# Altium schematic sheet 8_JTAG1_FLASH1_PMOD.SchDoc(8_JTAG1_FLASH1_PMOD)
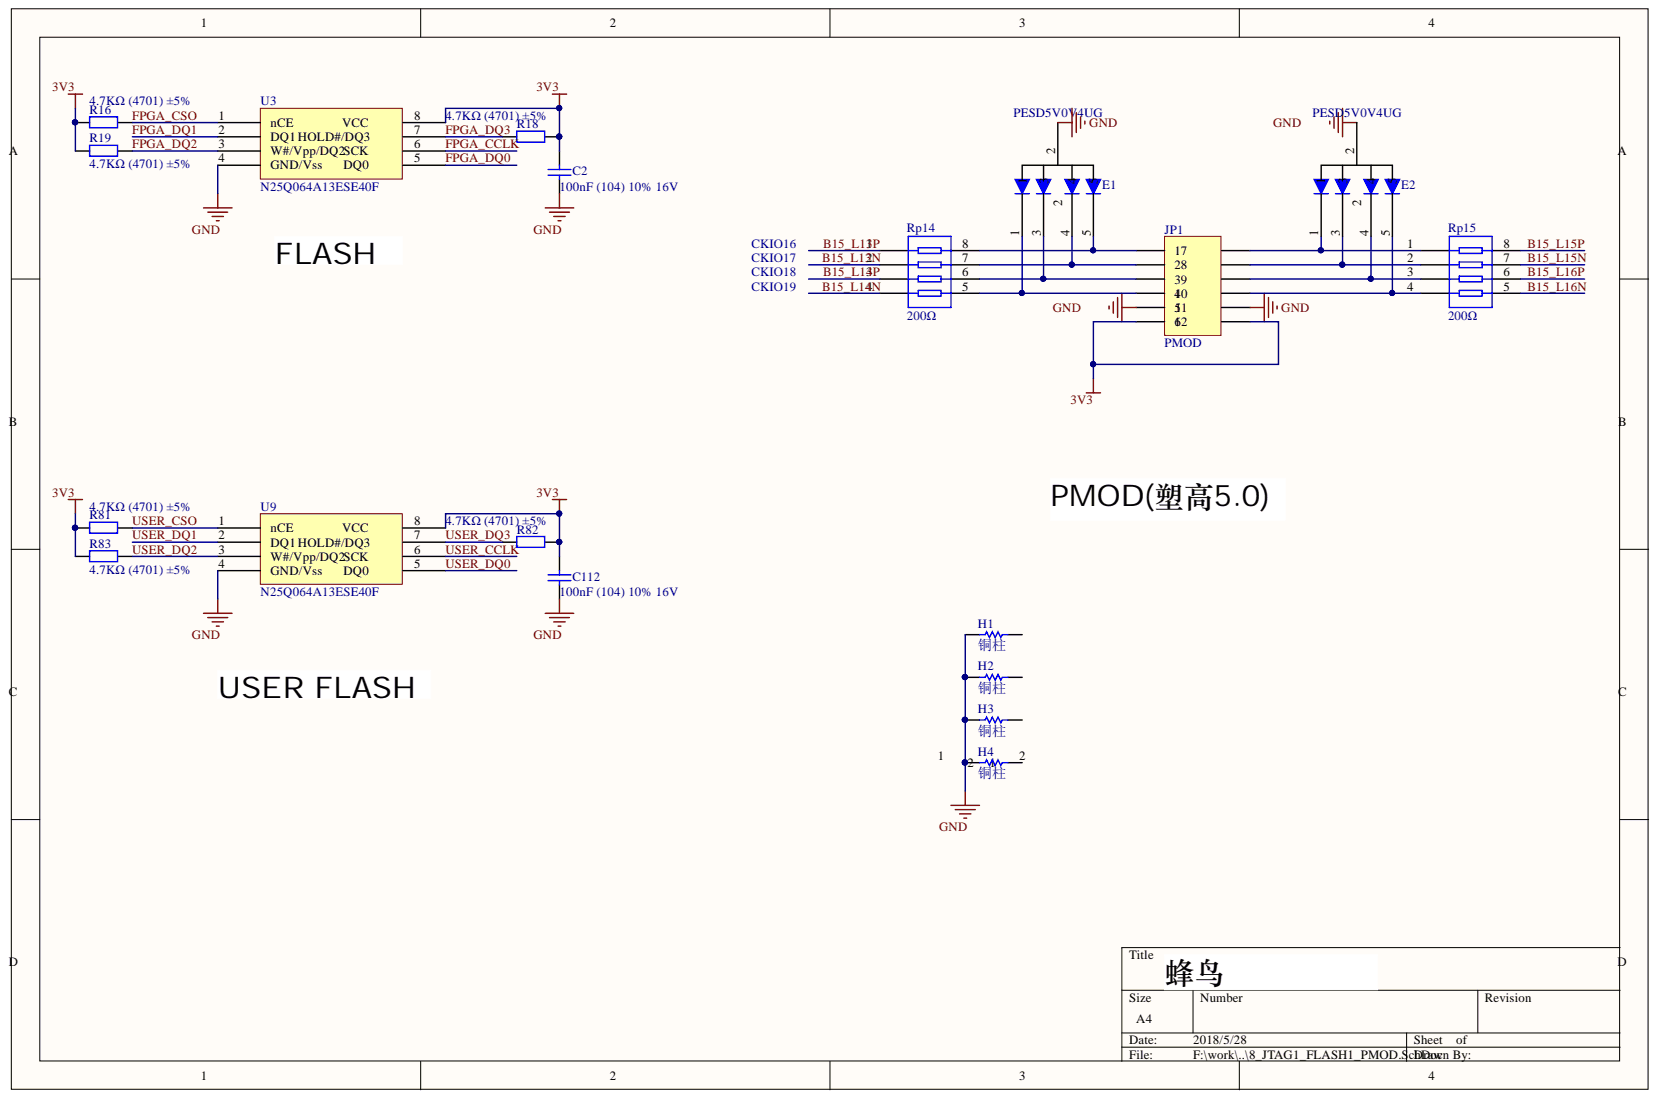
[diagram p0: 8_JTAG1_FLASH1_PMOD.SchDoc]

## Parts
C2  100nF (104) 10% 16V  [0402_C]
C112  100nF (104) 10% 16V  [0402_C]
E1  PESD5V0V4UG  [SOT353]
E2  PESD5V0V4UG  [SOT353]
H1  铜柱  [FPAD_1]
H2  铜柱  [FPAD_1]
H3  铜柱  [FPAD_1]
H4  铜柱  [FPAD_1]
JP1  PMOD  [PMOD]
R16  4.7KΩ (4701) ±5%  [0402_R]
R18  4.7KΩ (4701) ±5%  [0402_R]
R19  4.7KΩ (4701) ±5%  [0402_R]
R81  4.7KΩ (4701) ±5%  [0402_R]
R82  4.7KΩ (4701) ±5%  [0402_R]
R83  4.7KΩ (4701) ±5%  [0402_R]
Rp14  200Ω  [0402_Rx4]
Rp15  200Ω  [0402_Rx4]
U3  N25Q064A13ESE40F  [SO8W_208mil body]
U9  N25Q064A13ESE40F  [SO8W_208mil body]

## Nets
3V3: C2.2 C112.2 JP1.6 JP1.12 R16.1 R18.2 R19.1 R81.1 R82.2 R83.1 U3.8 U9.8
GND: C2.1 C112.1 E1.2 E2.2 H1.1 H2.1 H3.1 H4.1 JP1.5 JP1.11 U3.4(GND/Vss) U9.4(GND/Vss)
B15_L13N: Rp14.2
B15_L13P: Rp14.1
B15_L14N: Rp14.4
B15_L14P: Rp14.3
B15_L15N: Rp15.7
B15_L15P: Rp15.8
B15_L16N: Rp15.5
B15_L16P: Rp15.6
FPGA_CCLK: U3.6
FPGA_CSO: R16.2 U3.1(nCE)
FPGA_DQ0: U3.5
FPGA_DQ1: U3.2
FPGA_DQ2: R19.2 U3.3(W#/Vpp/DQ2)
FPGA_DQ3: R18.1 U3.7
USER_CCLK: U9.6
USER_CSO: R81.2 U9.1(nCE)
USER_DQ0: U9.5
USER_DQ1: U9.2
USER_DQ2: R83.2 U9.3(W#/Vpp/DQ2)
USER_DQ3: R82.1 U9.7
NetE1_1: E1.1 JP1.4 Rp14.5
NetE1_3: E1.3 JP1.3(3_9) Rp14.6
NetE1_4: E1.4 JP1.2(2_8) Rp14.7
NetE1_5: E1.5 JP1.1(1_7) Rp14.8
NetE2_1: E2.1 JP1.7 Rp15.1
NetE2_3: E2.3 JP1.8 Rp15.2
NetE2_4: E2.4 JP1.9 Rp15.3
NetE2_5: E2.5 JP1.10 Rp15.4

Not connected: H1 2; H2 2; H3 2; H4 2

## Library data of the parts
C2 C112 = 0402 100nF (104) 10% 16V: 贴片电容; Component Kind: Standard; ComponentLink1Description: 供应商链接; ComponentLink1URL: http://www.szlcsc.com/product/details_1877.html; Library Name: Miscellaneous Devices LC.IntLib; Package: 0402; Supplier: LC; SuppliersPartNumber: C1525
E1 E2 = PESD*4: Component Kind: Standard; Library Name: Personal_Accumulation_Sch.SchLib
H1-H4 = Res1: Resistor; Component Kind: Standard; LatestRevisionDate: 17-Jul-2002; LatestRevisionNote: Re-released for DXP Platform.; Library Name: Miscellaneous Devices.IntLib; PackageDescription: Axial Device, Thru-Hole; 2 Leads; 0.3 in Pin Spacing; PackageReference: AXIAL-0.3; Published: 8-Jun-2000; Signal Integrity: Res; Simulation: RESISTOR; Publisher: Altium Limited
JP1 = PMOD: Header, 2*6Pin,; Component Kind: Standard; Revision: 17-Jul-2002: Re-released for DXP Platform.; Signal Integrity: Connector; Library Name: Personal_Accumulation_Sch.SchLib; Publisher: Altium Limited
R16 R18 R19 R81-R83 = 0402 4.7KΩ (4701) 1%: 贴片电阻; Component Kind: Standard; ComponentLink1Description: 供应商链接; ComponentLink1URL: http://www.szlcsc.com/product/details_26643.html; Library Name: Miscellaneous Devices LC.IntLib; Package: 0402; Supplier: LC; SuppliersPartNumber: C25900
Rp14 Rp15 = 0402*4 33Ω (330) 5%: 贴片排阻; Component Kind: Standard; ComponentLink1Description: 供应商链接; ComponentLink1URL: http://www.szlcsc.com/product/details_26244.html; Library Name: Miscellaneous Devices LC.IntLib; Package: 0402*4; Supplier: LC; SuppliersPartNumber: C25501
U3 U9 = N25Q064A13ESE40F: FLASH芯片; Component Kind: Standard; Library Name: N25Q064A13ESE40F.SchLib
